# Altium schematic sheet Sheet1.SchDoc
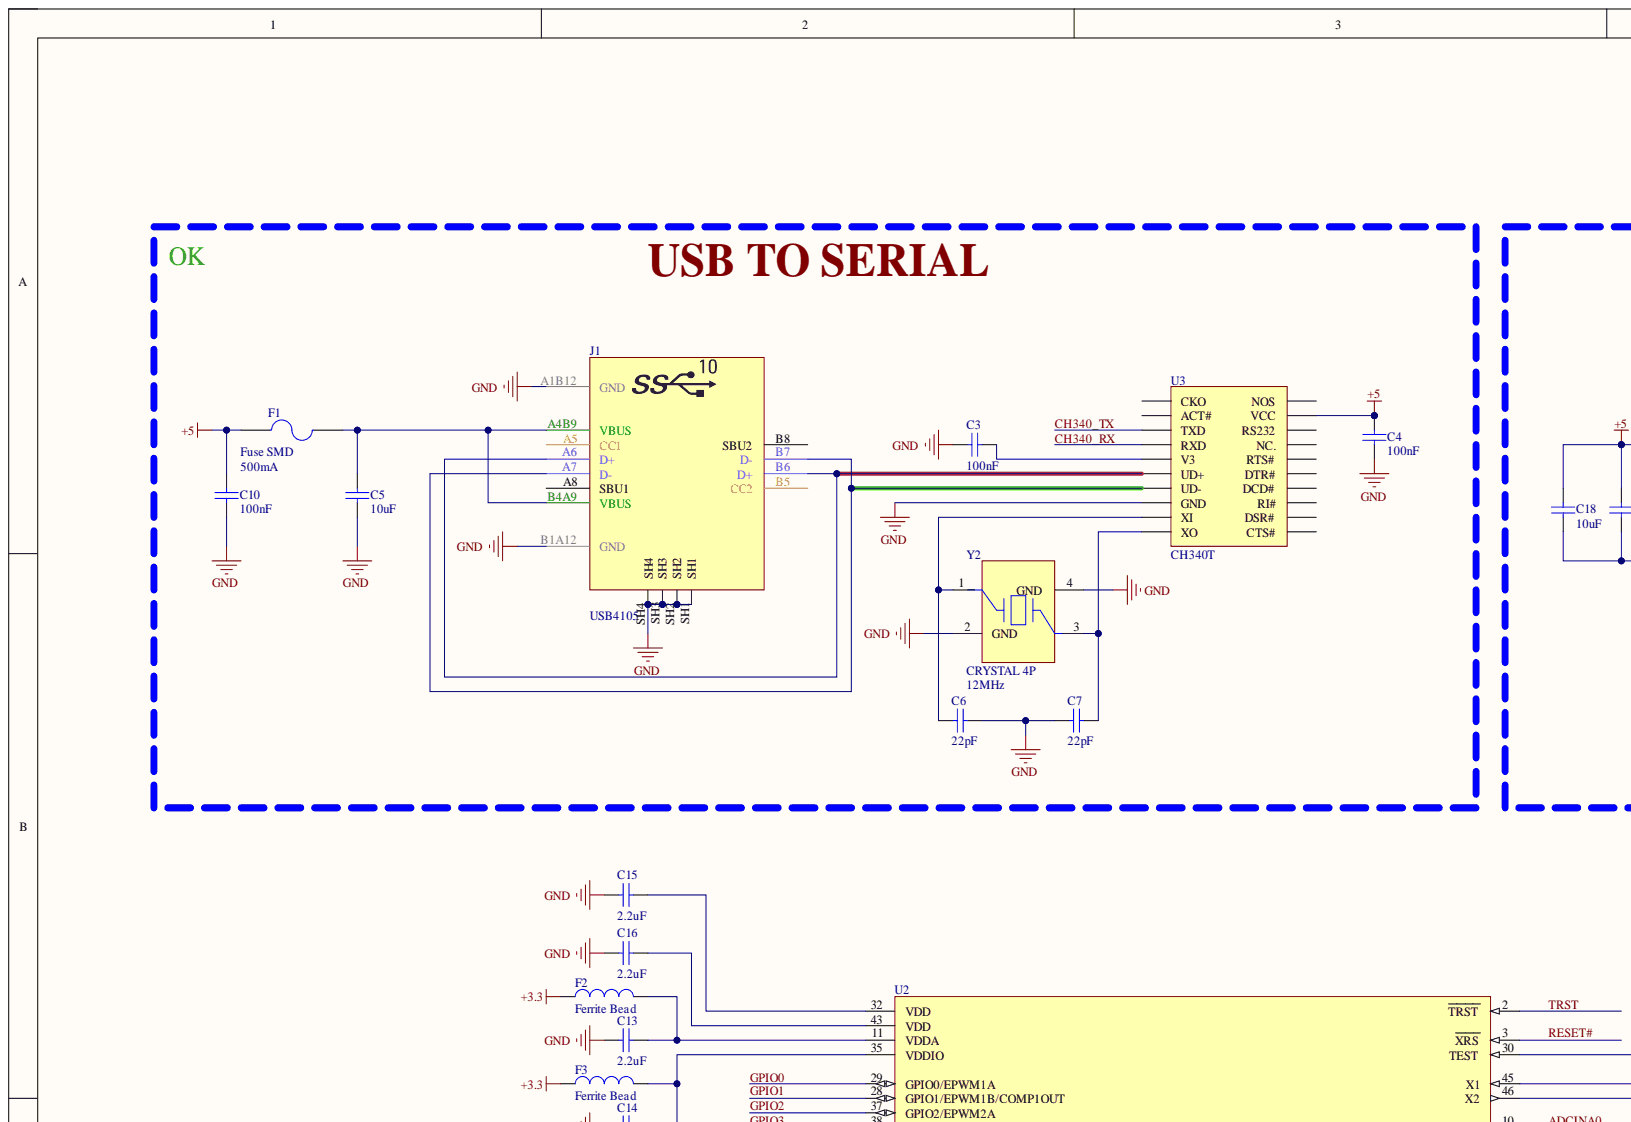
[diagram p0: Sheet1.SchDoc, top left]
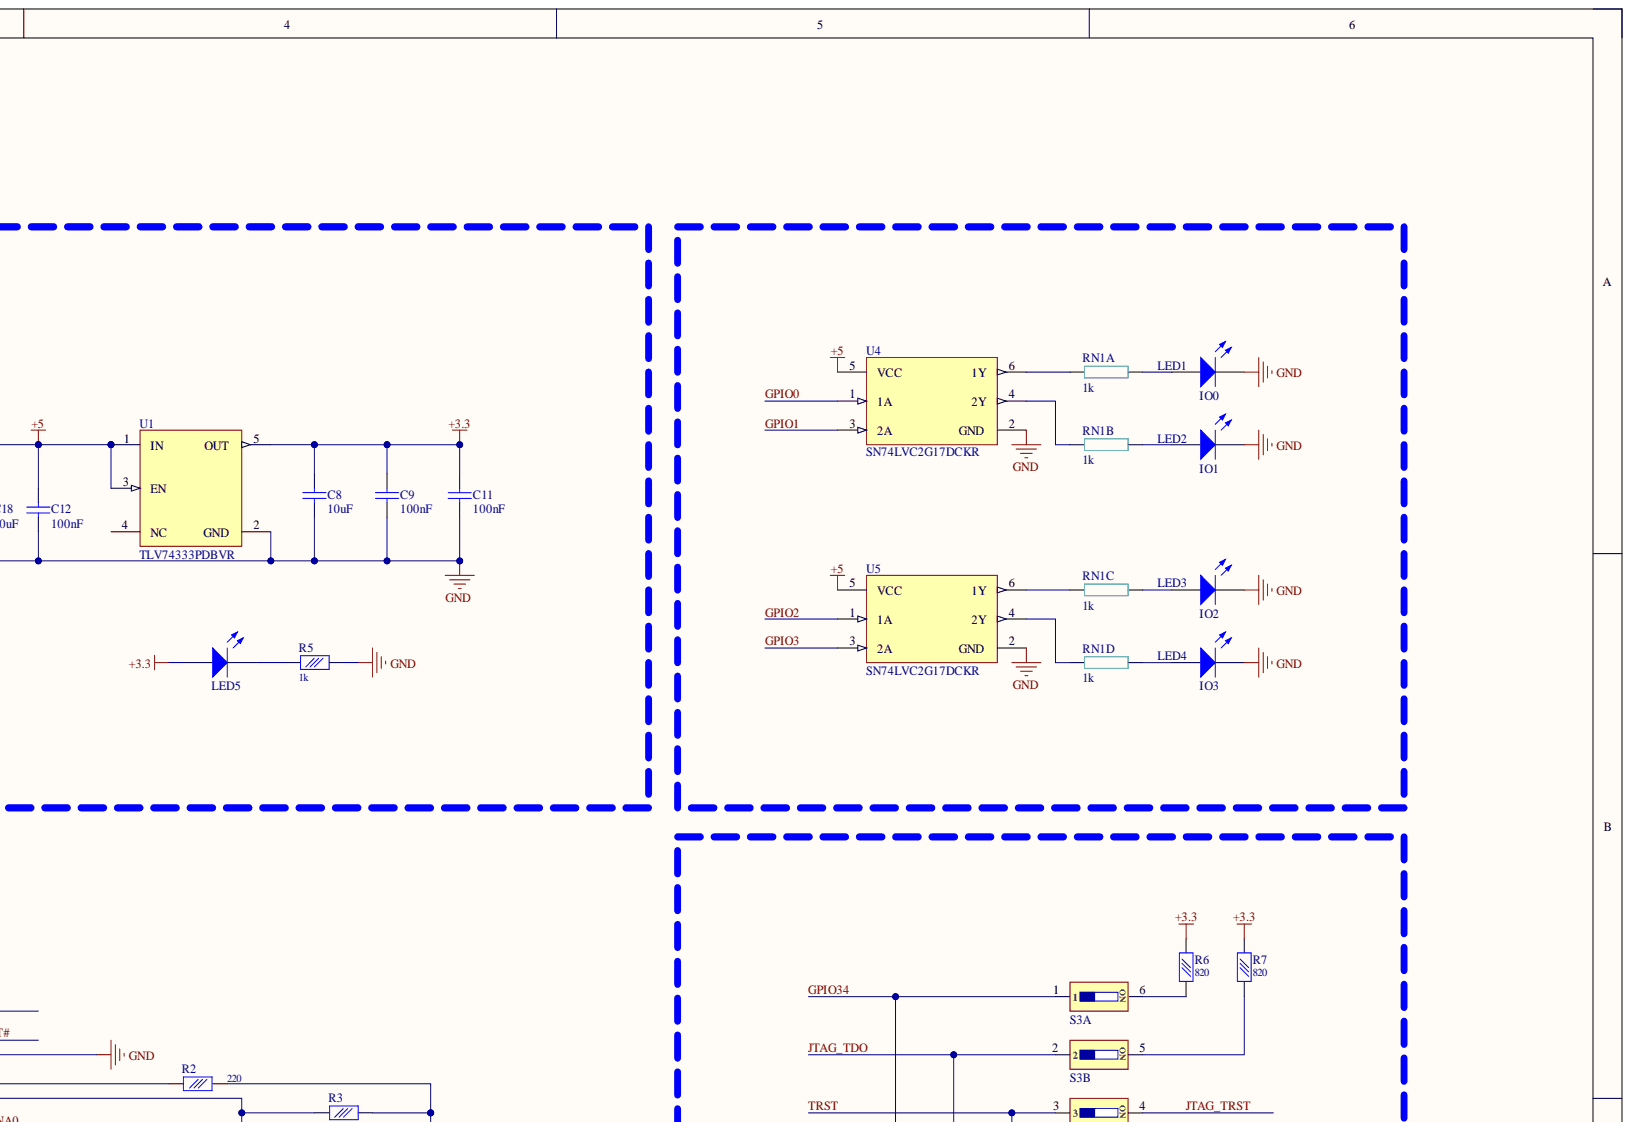
[diagram p1: Sheet1.SchDoc, top right]
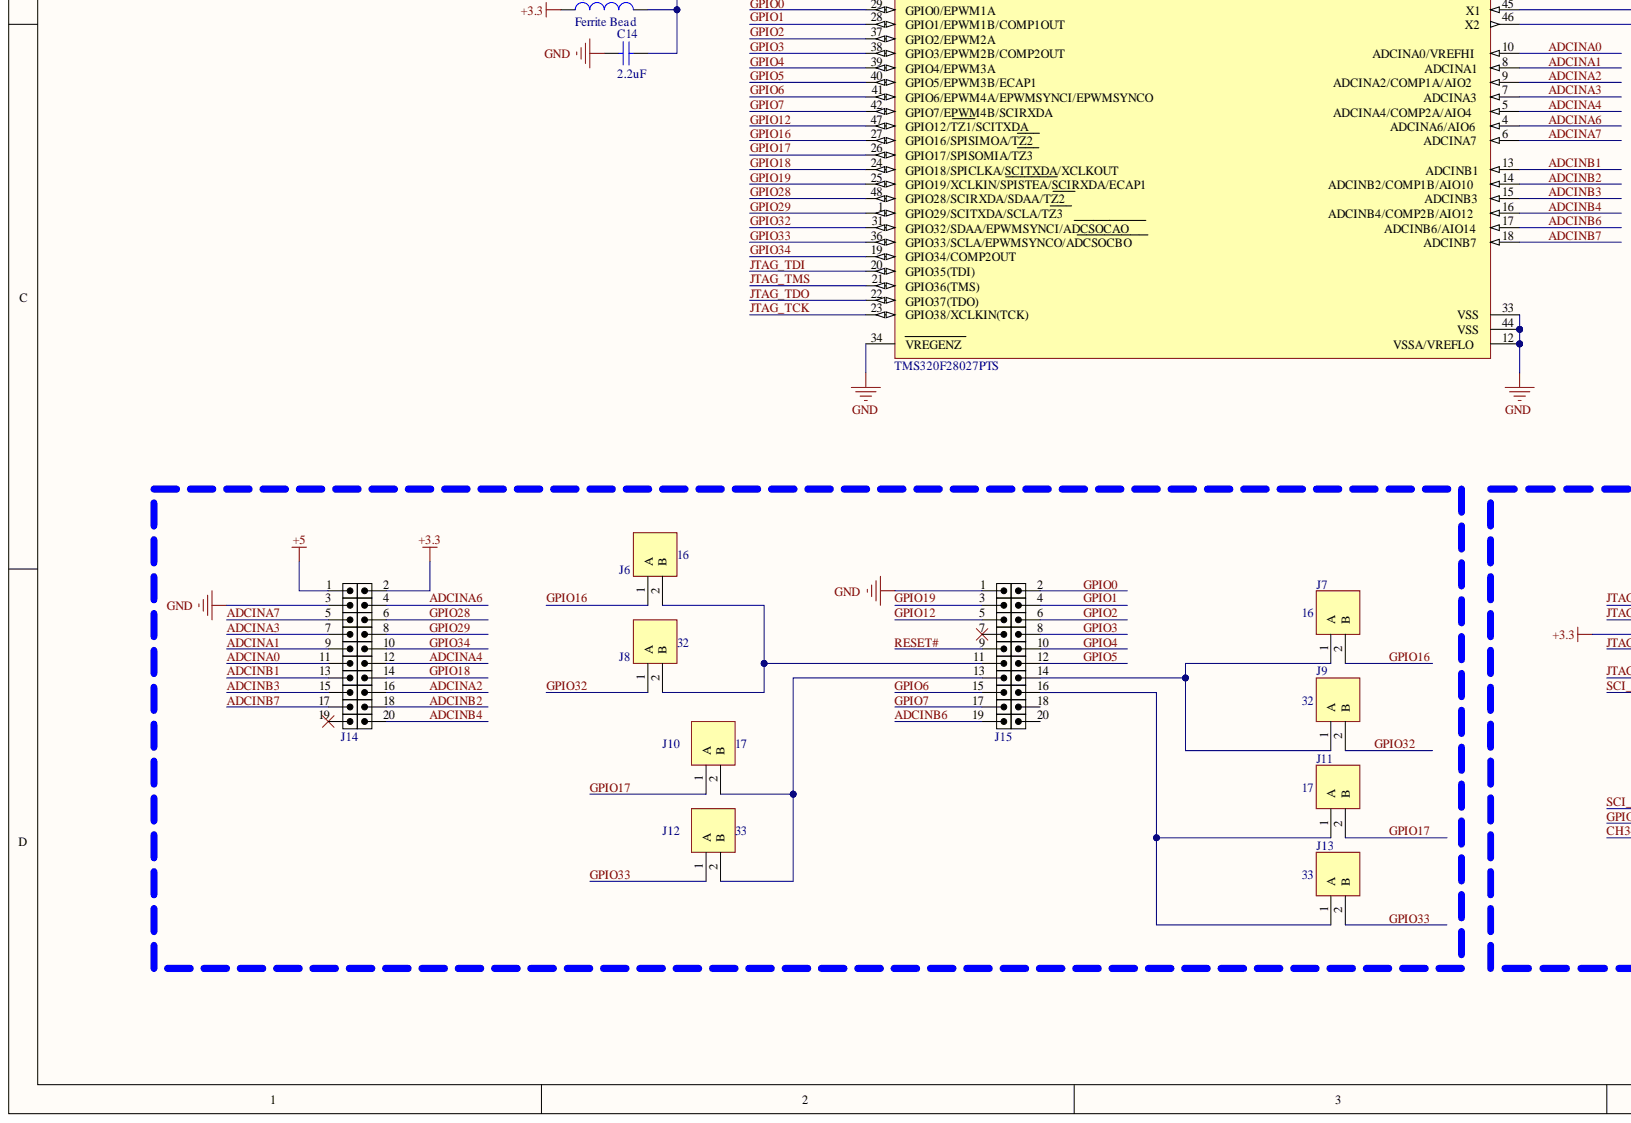
[diagram p2: Sheet1.SchDoc, bottom left]
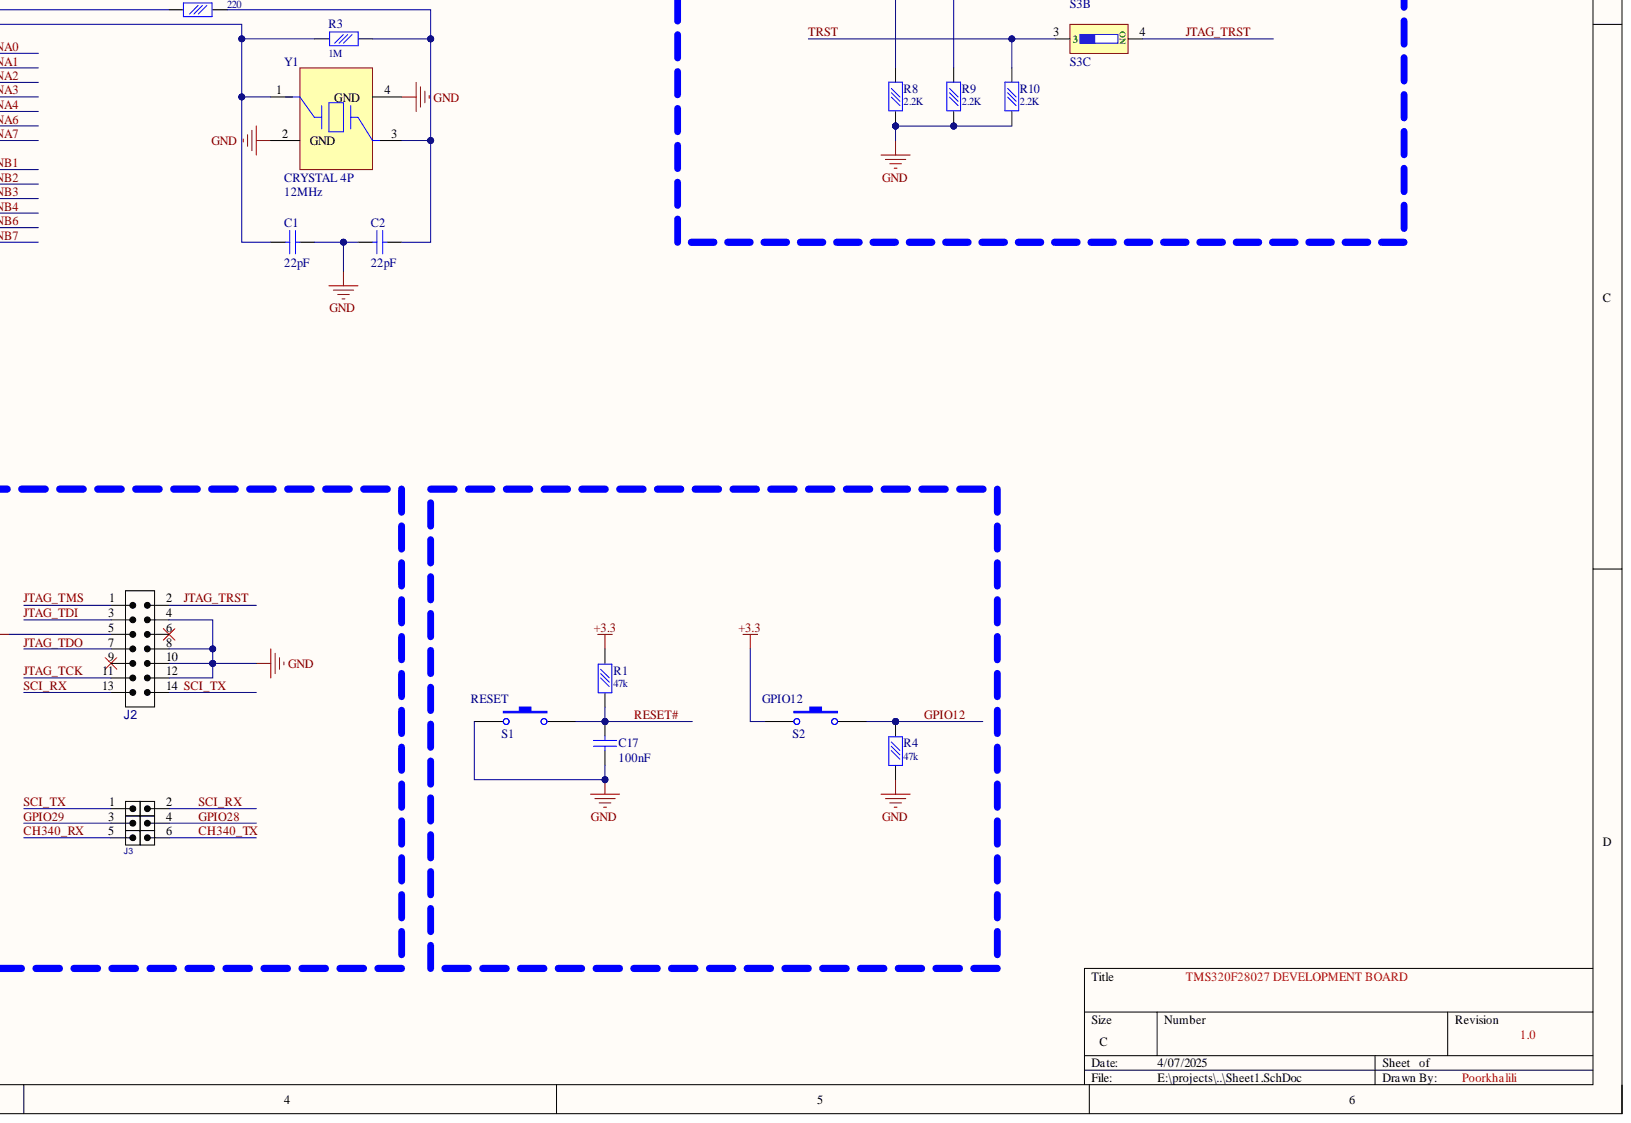
[diagram p3: Sheet1.SchDoc, bottom right]

## Parts
C1  value 22pF  [CAP 0603/1608]  (hidden comment: chip_cap)
C2  value 22pF  [CAP 0603/1608]  (hidden comment: chip_cap)
C3  value 100nF  [CAP 0805]  (hidden comment: chip_cap)
C4  value 100nF  [CAP 0805]  (hidden comment: chip_cap)
C5  value 10uF  [CAP 1206/3216 - 1.0MM]  (hidden comment: chip_cap)
C6  value 22pF  [CAP 0603/1608]  (hidden comment: chip_cap)
C7  value 22pF  [CAP 0603/1608]  (hidden comment: chip_cap)
C8  value 10uF  [CAP 1206/3216 - 1.0MM]  (hidden comment: chip_cap)
C9  value 100nF  [CAP 0805]  (hidden comment: chip_cap)
C10  value 100nF  [CAP 0805]  (hidden comment: chip_cap)
C11  value 100nF  [CAP 0805]  (hidden comment: chip_cap)
C12  value 100nF  [CAP 0805]  (hidden comment: chip_cap)
C13  value 2.2uF  [CAP 0805]  (hidden comment: chip_cap)
C14  value 2.2uF  [CAP 0805]  (hidden comment: chip_cap)
C15  value 2.2uF  [CAP 0805]  (hidden comment: chip_cap)
C16  value 2.2uF  [CAP 0805]  (hidden comment: chip_cap)
C17  value 100nF  [CAP 0805]  (hidden comment: chip_cap)
C18  value 10uF  [CAP 1206/3216 - 1.0MM]  (hidden comment: chip_cap)
F1  Fuse SMD  value 500mA  [RES 1206]
F2  Ferrite Bead  [RES 0805]
F3  Ferrite Bead  [RES 0805]
J1  value USB4105  [USBC_SMD_16_USB4105]  (hidden comment: Debug Port)
J2  IDC_2X7  [IDC_2X7]  (value not drawn)
J3  HDRM_2X3  [HDR_M_2X3]  (value not drawn)
J6  16  [JPAD_2pin]
J7  16  [JPAD_2pin]
J8  32  [JPAD_2pin]
J9  32  [JPAD_2pin]
J10  17  [JPAD_2pin]
J11  17  [JPAD_2pin]
J12  33  [JPAD_2pin]
J13  33  [JPAD_2pin]
J14  HDRM_2X10  [HDR_M_2X10]  (value not drawn)
J15  HDRM_2X10  [HDR_M_2X10]  (value not drawn)
LED1  IO0  [LEDC1608X60N]
LED2  IO1  [LEDC1608X60N]
LED3  IO2  [LEDC1608X60N]
LED4  IO3  [LEDC1608X60N]
LED5  LED  [LEDC1608X60N]
R1  value 47k  [RES 0805]  (hidden comment: chip_res)
R2  value 220  [RES 0603]  (hidden comment: chip_res)
R3  value 1M  [RES 0603]  (hidden comment: chip_res)
R4  value 47k  [RES 0805]  (hidden comment: chip_res)
R5  value 1k  [RES 0805]  (hidden comment: chip_res)
R6  value 820  [RES 0603]  (hidden comment: chip_res)
R7  value 820  [RES 0603]  (hidden comment: chip_res)
R8  value 2.2K  [RES 0603]  (hidden comment: chip_res)
R9  value 2.2K  [RES 0603]  (hidden comment: chip_res)
R10  value 2.2K  [RES 0603]  (hidden comment: chip_res)
RN1  value 1k  [RESCAXS80P320X160X50-8N]  (hidden comment: ARRAY RES)  (parts A,B,C,D)
S1  RESET  [tactile-micro-push-button]
S2  GPIO12  [tactile-micro-push-button]
S3  DIPSW3  [DIPSW254S_3]  (value not drawn)  (parts A,B,C)
U1  TLV74333PDBVR  [FP-DBV0005A-MFG]
U2  TMS320F28027PTS  [FP-PT0048A-MFG]
U3  CH340T  [SSOP20_L]
U4  SN74LVC2G17DCKR  [FP-DCK0006A-MFG]
U5  SN74LVC2G17DCKR  [FP-DCK0006A-MFG]
Y1  CRYSTAL 4P  Frequency: 12MHz  [XTL_4_3225]
Y2  CRYSTAL 4P  [XTL_4_3225]

## Nets
+3.3: C8.2 C9.2 C11.2 F2.1 F3.1 J2.5 J14.2 LED5.A R1.2 R6.1 R7.1 S2.1 U1.5(OUT)
+5: C4.1 C10.2 C12.2 C18.2 F1.2 J14.1 U1.1(IN) U1.3(EN) U3.19(VCC) U4.5(VCC) U5.5(VCC)
GND: C1.1 C2.2 C3.2 C4.2 C5.1 C6.2 C7.1 C8.1 C9.1 C10.1 C11.1 C12.1 C13.1 C14.1 C15.1 C16.1 C17.2 C18.1 J1.A1B12(GND) J1.B1A12(GND) J1.SH1 J1.SH2 J1.SH3 J1.SH4 J2.4 J2.8 J2.10 J2.12 J14.3 J15.1 LED1.K LED2.K LED3.K LED4.K R4.1 R5.1 R8.2 R9.2 R10.2 S1.1 U1.2(GND) U2.12(VSSA/VREFLO) U2.30(TEST) U2.33(VSS) U2.34(VREGENZ) U2.44(VSS) U3.8(GND) U4.2(GND) U5.2(GND) Y1.2(GND) Y1.4(GND) Y2.2(GND) Y2.4(GND)
ADCINA0: J14.11 U2.10(ADCINA0/VREFHI)
ADCINA1: J14.9 U2.8(ADCINA1)
ADCINA2: J14.16 U2.9(ADCINA2/COMP1A/AIO2)
ADCINA3: J14.7 U2.7(ADCINA3)
ADCINA4: J14.12 U2.5(ADCINA4/COMP2A/AIO4)
ADCINA6: J14.4 U2.4(ADCINA6/AIO6)
ADCINA7: J14.5 U2.6(ADCINA7)
ADCINB1: J14.13 U2.13(ADCINB1)
ADCINB2: J14.18 U2.14(ADCINB2/COMP1B/AIO10)
ADCINB3: J14.15 U2.15(ADCINB3)
ADCINB4: J14.20 U2.16(ADCINB4/COMP2B/AIO12)
ADCINB6: J15.19 U2.17(ADCINB6/AIO14)
ADCINB7: J14.17 U2.18(ADCINB7)
CH340_RX: J3.5 U3.4(RXD)
CH340_TX: J3.6 U3.3(TXD)
GPIO0: J15.2 U2.29(GPIO0/EPWM1A) U4.1(1A)
GPIO1: J15.4 U2.28(GPIO1/EPWM1B/COMP1OUT) U4.3(2A)
GPIO2: J15.6 U2.37(GPIO2/EPWM2A) U5.1(1A)
GPIO3: J15.8 U2.38(GPIO3/EPWM2B/COMP2OUT) U5.3(2A)
GPIO4: J15.10 U2.39(GPIO4/EPWM3A)
GPIO5: J15.12 U2.40(GPIO5/EPWM3B/ECAP1)
GPIO6: J15.15 U2.41(GPIO6/EPWM4A/EPWMSYNCI/EPWMSYNCO)
GPIO7: J15.17 U2.42(GPIO7/EPWM4B/SCIRXDA)
GPIO12: J15.5 R4.2 S2.2 U2.47(GPIO12/TZ1/SCITXDA)
GPIO16: J6.1 J7.2 U2.27(GPIO16/SPISIMOA/TZ2)
GPIO17: J10.1 J11.2 U2.26(GPIO17/SPISOMIA/TZ3)
GPIO18: J14.14 U2.24(GPIO18/SPICLKA/SCITXDA/XCLKOUT)
GPIO19: J15.3 U2.25(GPIO19/XCLKIN/SPISTEA/SCIRXDA/ECAP1)
GPIO28: J3.4 J14.6 U2.48(GPIO28/SCIRXDA/SDAA/TZ2)
GPIO29: J3.3 J14.8 U2.1(GPIO29/SCITXDA/SCLA/TZ3)
GPIO32: J8.1 J9.2 U2.31(GPIO32/SDAA/EPWMSYNCI/ADCSOCAO)
GPIO33: J12.1 J13.2 U2.36(GPIO33/SCLA/EPWMSYNCO/ADCSOCBO)
GPIO34: J14.10 R8.1 S3.1 U2.19(GPIO34/COMP2OUT)
JTAG_TCK: J2.11 U2.23(GPIO38/XCLKIN(TCK))
JTAG_TDI: J2.3 U2.20(GPIO35(TDI))
JTAG_TDO: J2.7 R9.1 S3.2 U2.22(GPIO37(TDO))
JTAG_TMS: J2.1 U2.21(GPIO36(TMS))
JTAG_TRST: J2.2 S3.4(ON)
RESET#: C17.1 J15.9 R1.1 S1.2 U2.3(XRS)
SCI_RX: J2.13 J3.2
SCI_TX: J2.14 J3.1
TRST: R10.1 S3.3 U2.2(TRST)
NetC1_2: C1.2 R3.2 U2.46(X2) Y1.1
NetC2_1: C2.1 R2.2 R3.1 Y1.3
NetC3_1: C3.1 U3.5(V3)
NetC5_2: C5.2 F1.1 J1.A4B9(VBUS) J1.B4A9(VBUS)
NetC6_1: C6.1 U3.9(XI) Y2.1
NetC7_2: C7.2 U3.10(XO) Y2.3
NetC13_2: C13.2 F2.2 U2.11(VDDA)
NetC14_2: C14.2 F3.2 U2.35(VDDIO)
NetC15_2: C15.2 U2.32(VDD)
NetC16_2: C16.2 U2.43(VDD)
NetJ1_A6: J1.A6(D+) J1.B6(D+) U3.6(UD+)
NetJ1_A7: J1.A7(D-) J1.B7(D-) U3.7(UD-)
NetJ6_2: J6.2 J8.2 J15.11
NetJ7_1: J7.1 J9.1 J15.14
NetJ10_2: J10.2 J12.2 J15.13
NetJ11_1: J11.1 J13.1 J15.16
NetLED1_A: LED1.A RN1.8
NetLED2_A: LED2.A RN1.7
NetLED3_A: LED3.A RN1.6
NetLED4_A: LED4.A RN1.5
NetLED5_K: LED5.K R5.2
NetR2_1: R2.1 U2.45(X1)
NetR6_2: R6.2 S3.6(ON)
NetR7_2: R7.2 S3.5(ON)
NetRN1_1: RN1.1 U4.6(1Y)
NetRN1_2: RN1.2 U4.4(2Y)
NetRN1_3: RN1.3 U5.6(1Y)
NetRN1_4: RN1.4 U5.4(2Y)
USB TO SERIAL:

Not connected: J1 A5(CC1) A8(SBU1) B5(CC2) B8(SBU2); J2 6 9; J14 19; J15 7 18 20; U1 4(NC); U3 1(CKO) 2(ACT#) 11(CTS#) 12(DSR#) 13(RI#) 14(DCD#) 15(DTR#) 16(RTS#) 17(NC.) 18(RS232) 20(NOS)

## Library data of the parts
C1-C18 = chip_cap: Capacitor (Semiconductor SIM Model); Component Kind: Standard; LatestRevisionDate: 29-May-2009; LatestRevisionNote: IPC-7351 Footprint Added.; PackageDescription: Chip Capacitor; PackageReference: C1206; Published: 8-Jun-2000; Publisher: Altium Limited
  C3-C18: Library Name: @mylib_passive.IntLib
  C1 C2: Library Name: Schlibrary.SchLib
F1 = Fuse SMD: Value: 500mA; Component Kind: Standard; Description: Fuse SMD; LatestRevisionDate: 17-Jul-2002; LatestRevisionNote: Re-released for DXP Platform.; Library Name: @mylib_passive.IntLib; PackageReference: PIN-W2/E2.8; Published: 8-Jun-2000; Publisher: Altium Limited
F2 F3 = Ferrite Bead: Code_IEC: 1005; Code_JEITA: 0402; Component Kind: Standard; Description: Ferrite Bead; LatestRevisionDate: 29-May-2009; LatestRevisionNote: IPC-7351 Footprint Added.; PackageDescription: Chip Inductor; PackageReference: 0402-A; Published: 8-Jun-2000; Library Name: Schlibrary.SchLib; Publisher: Altium Limited
J1 = CONN_USBC_SMD_16_USB4105: CONN, USB, Type C, USB 2.0, Right-Angle, SMD; MANF: GCT; MANF_P#: USB4105-GF-A; PARAM: 5A; Component Kind: Standard; Library Name: conn_usbc.SchLib; Price: 1.5$
J2 = IDC_2X7: CONN, IDC, Male, pitch: 2.54mm, 14POS; Component Kind: Standard; Library Name: conn_header_2.54mm_box_idc.SchLib; VALUE: IDC_2X7
J3 = HDR_M_2X3: CONN, HEADER, Male, pitch: 2.54mm, 6POS; MANF_P#: MANF_PART#; Component Kind: Standard; Library Name: conn_header_2.54mm_st.SchLib; MANF: MANF; VALUE: HDRM_2X3
J6-J13 = JPAD_2pin: Component Kind: Standard; Library Name: @Connector.IntLib
J14 J15 = HDR_M_2X10: CONN, HEADER, Male, pitch: 2.54mm, 20POS; Component Kind: Standard; Library Name: conn_header_2.54mm_st.SchLib; VALUE: HDRM_2X10
LED1-LED5 = LED: Typical RED GaAs LED; Component Kind: Standard; LatestRevisionDate: 08-Jul-2005; LatestRevisionNote: Mech Layer 1 removed.; Library Name: @my_lib.IntLib; PackageDescription: LED; 2 Leads; PackageReference: LED-1; Published: 8-Jun-2000; Publisher: Altium Limited
R1-R10 = chip_res: Resistor; Component Kind: Standard; LatestRevisionDate: 29-May-2009; LatestRevisionNote: IPC-7351 Footprint Added.; PackageDescription: Chip Resistor; PackageReference: J1-0603; Published: 8-Jun-2000; Publisher: Altium Limited
  R1 R4-R10: Library Name: @mylib_passive.IntLib
  R2 R3: Library Name: Schlibrary.SchLib
S1 S2 = tactile-micro-push-button: Switch; Component Kind: Standard; LatestRevisionDate: 17-Jul-2002; LatestRevisionNote: Re-released for DXP Platform.; Library Name: @my-switch.IntLib; PackageDescription: Switch; 2 Leads; PackageReference: SPST-2; Published: 24-Mar-1999; Publisher: Altium Limited
S3 = DIPSW254S_3P: SWITCH, DIP, 3P, THD; Component Kind: Standard; Library Name: switch_dip.SchLib; MANF: MANF; MANF_P#: MANF_P#; VALUE: DIPSW3
U1 = CMP-04917-000051-1: IC REG LINEAR 3.3V 300MA SOT23-5; Manufacturer: Texas Instruments; Accuracy Percentage: 1%; Dropout Voltage: 125mV; Max Junction Temp: +125°C; Max Supply Voltage: 5.5V; Min Supply Voltage: 1.4V; Nominal Supply Current: 34uA; Number Of Outputs: 1; Output Type: Fixed; Output Voltage: 1-3.3V; Standoff Height: 0mm; Automotive: No; Category: IC; Component Kind: Standard; ComponentLink2Description: Datasheet; ComponentLink2URL: http://www.ti.com/lit/ds/symlink/tlv743p.pdf; Device Class L1: Integrated Circuits (ICs); Device Class L2: Power Management ICs; Device Class L3: Voltage Regulators - Linear; Digikey Description: IC REG LINEAR 3.3V 300MA SOT23-5; Height: 1.45mm; Lead Free: Yes; Library Name: Altium Content Vault; Manufacturer Part Number: TLV74333PDBVR; Mouser Description: 300mA Low-Dropout (LDO) Regulator 5-SOT-23 -40 to 125; Octopart: https://octopart.com/tlv74333pdbvr-texas+instruments-84791760; Output Current: 300mA; Package: DBV0005A; RoHS: TRUE; Temperature: -40°C to +125°C; Temperature Range High: +125°C; Temperature Range Low: -40°C
U2 = TMS320F28027PTS: IC MCU 32BIT 64KB FLASH 48LQFP; Core Architecture: RISC; Data Bus Width: 32b; Frequency: 60MHz; Interface: I2C; Max Supply Voltage: 1.99V; Min Supply Voltage: 2.97V; Number of A/D Converters: 1; Number of ADC Channels: 13; Number of Bits: 32; Number of I/Os: 22; Number of PWM Channels: 8; Number of Timers/Counters: 3; Operating Supply Voltage: 3.3V; Oscillator Type: Internal; Peripherals: Brown-out Detect/Reset; RAM Size: 12KB; Watchdog Timer: Yes; Case/Package: LQFP; Component Kind: Standard; Contact Plating: Gold; Height: 1.45mm; Lead Free: Lead Free; Length: 7.2mm; Library Name: STM32_F7_H7_MP1.IntLib; Max Frequency: 60MHz; Max Operating Temperature: 105°C; Memory Size: 64KB; Memory Type: FLASH; Min Operating Temperature: -40°C; Mount: Surface Mount; Number of I2C Channels: 1; Number of Pins: 48; Number of Programmable I/O: 22; Number of SPI Channels: 1; Number of UART Channels: 1; Package Quantity: 250; Packaging: Tray; Radiation Hardening: No; REACH SVHC: No SVHC; RoHS Compliant: Yes; Series: C2000™ C28x Piccolo™; Width: 7.2mm
U4 U5 = CMP-0859-00517-5: IC BUF NON-INVERT 5.5V SC70-6; High Level Output Current: -32mA; Logic Function: Schmitt Trigger; Low Level Output Current: 32mA; Min Supply Voltage: 1.65V; Nominal Supply Current: 250mA; Number of Bits: 2; Number of Bits per Element: 1; Polarity: Non-Inverting; Propagation Delay: 4.3ns; Quiescent Current: 10uA; Schmitt Trigger Input: Yes; Turn-On Delay Time: 9.3ns; Case/Package: SC; Component Kind: Standard; Contact Plating: Gold; Height: 0.9mm; Lead Free: Lead Free; Length: 2mm; Library Name: Altium Content Vault; Max Operating Temperature: 125°C; Max Supply Voltage: 5.5V; Min Operating Temperature: -40°C; Mount: Surface Mount; Number of Channels: 2; Number of Circuits: 2; Number of Elements: 2; Number of Gates: 2; Number of Input Lines: 2; Number of Inputs: 2; Number of Output Lines: 2; Number of Outputs: 2; Number of Pins: 6; Package Quantity: 1; Packaging: Tape and Reel; Radiation Hardening: No; REACH SVHC: No SVHC; RoHS Compliant: Yes; Termination: SMD/SMT; Width: 1.25mm
Y1 = CRYSTAL SMD 4P: CRYSTAL 4P-24MHZ 8PF SMD; ESR (Equivalent Series Resistance): 80R; Frequency Stability: 30ppm; Frequency Tolerance: 20ppm; Case/Package: SMD/SMT; Component Kind: Standard; Height: 0.035inch; Length: 0.126inch; Library Name: @my_lib.IntLib; Load Capacitance: 8pF; Max Operating Temperature: 85°C; Min Operating Temperature: -40°C; Mount: Surface Mount; Number of Pins: 4; Package Quantity: 3000; Packaging: Tape and Reel; Width: 0.098inch
Y2 = CRYSTAL SMD 4P: CRYSTAL 4P-24MHZ 8PF SMD; ESR (Equivalent Series Resistance): 80R; Frequency: 12MHz; Frequency Stability: 30ppm; Frequency Tolerance: 20ppm; Case/Package: SMD/SMT; Component Kind: Standard; Height: 0.035inch; Length: 0.126inch; Library Name: @my_lib.IntLib; Load Capacitance: 8pF; Max Operating Temperature: 85°C; Min Operating Temperature: -40°C; Mount: Surface Mount; Number of Pins: 4; Package Quantity: 3000; Packaging: Tape and Reel; Width: 0.098inch
RN1 = ARRAY RES: Component Kind: Standard; Library Name: @mylib_passive.IntLib
U3 = CH340T: Component Kind: Standard; Library Name: my_lib.IntLib
